# Altium schematic sheet Sheet1.SchDoc
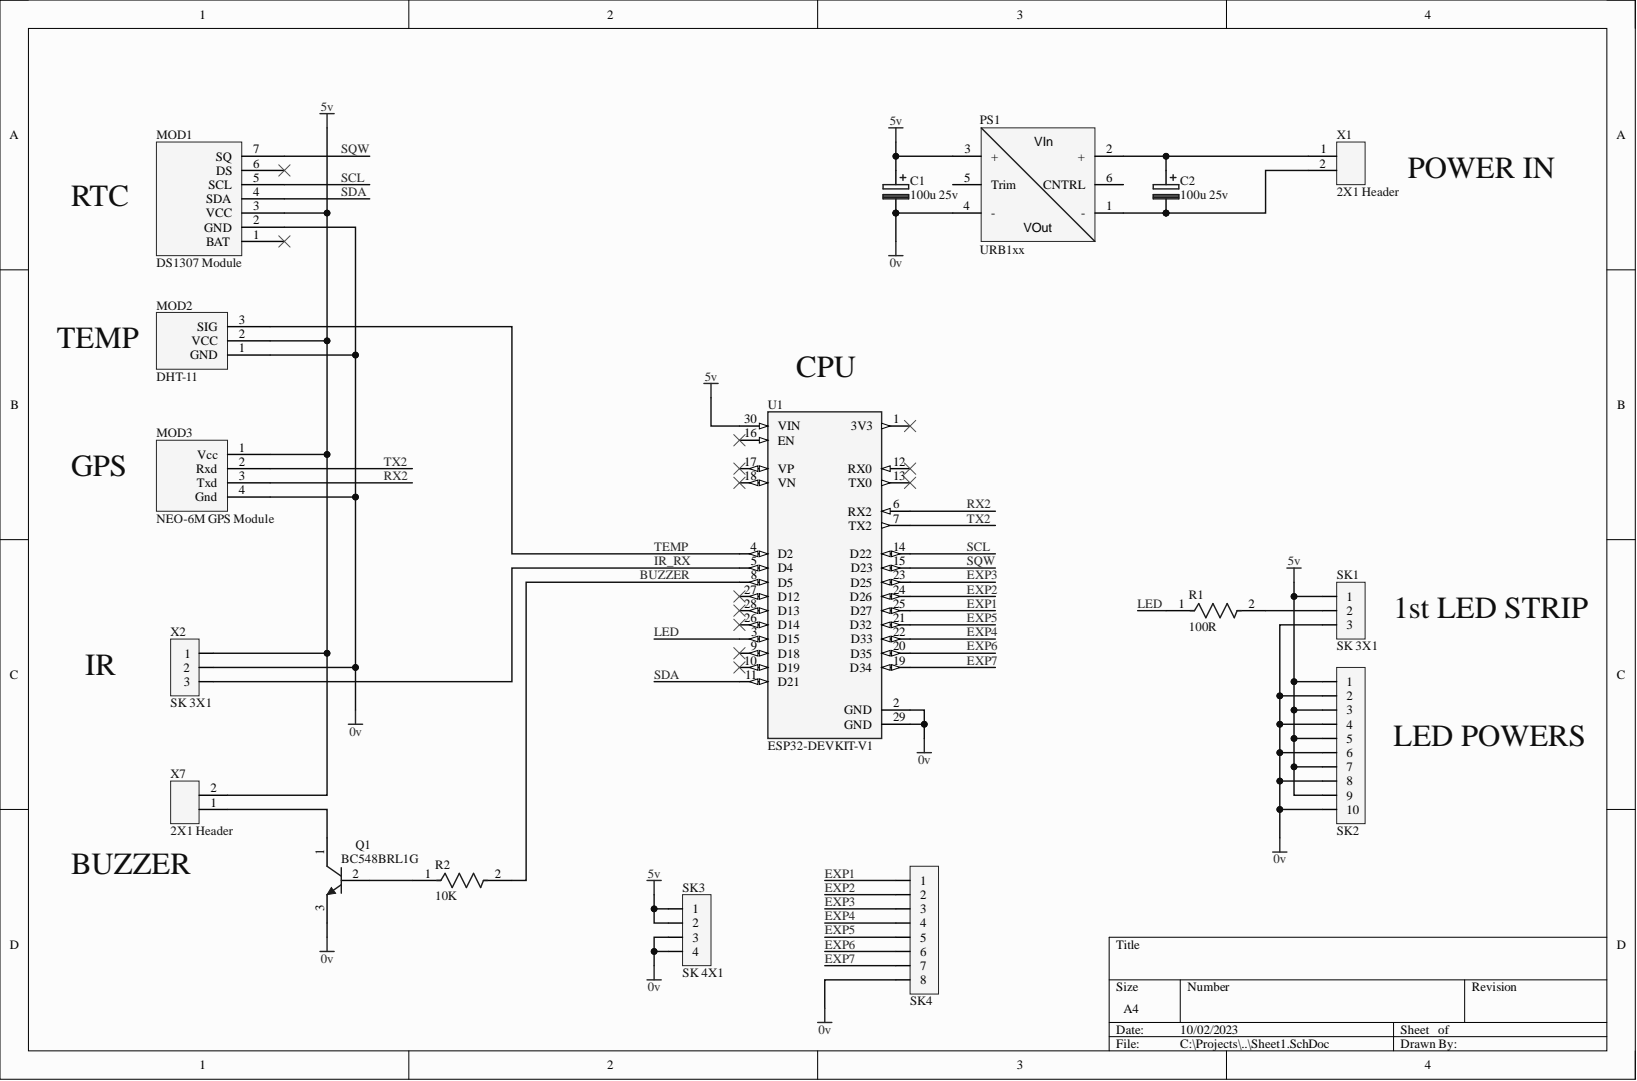
[diagram p0: Sheet1.SchDoc]

## Parts
C1  100u 25v  [RB 2.5/6]
C2  100u 25v  [RB 2.5/6]
MOD1  DS1307 Module  [DS1307 Module]
MOD2  DHT-11  [DHT11]
MOD3  NEO-6M GPS Module  [GY-GPS6MV2]
PS1  URB1xx  [PS DC/DC DA0xx]
Q1  BC548BRL1G  [ONSC-TO-92-3-29-11_AM_BL]
R1  100R  [FP-CF18-MFG]
R2  10K  [FP-CF18-MFG]
SK1  SK 3X1  [TB 3X1 5.08 V]
SK2  SK 10X1  [SK 10x1 5.08 R/A]  (value not drawn)
SK3  SK 4X1  [SK 4X1 Vert M]
SK4  SK 8X1  [SK 8X1 2.54 V]  (value not drawn)
U1  ESP32-DEVKIT-V1  [MODULE_ESP32_DEVKIT_V1]
X1  2X1 Header  [TB 2X1 5.08 V]
X2  SK 3X1  [TB 3X1 5.08 V]
X7  2X1 Header  [TB 2X1 5.08 V]

## Nets
0v: C1.2 MOD1.2(GND) MOD2.1 MOD3.4(Gnd) PS1.4(-) Q1.3 SK1.3 SK2.2 SK2.4 SK2.6 SK2.8 SK2.10 SK3.3 SK3.4 SK4.8 U1.2(GND) U1.29(GND) X2.2
5v: C1.1 MOD1.3(VCC) MOD2.2 MOD3.1(Vcc) PS1.3(+) SK1.1 SK2.1 SK2.3 SK2.5 SK2.7 SK2.9 SK3.1 SK3.2 U1.30(VIN) X2.1 X7.2
BUZZER: R2.2 U1.8(D5)
EXP1: SK4.1 U1.25(D27)
EXP2: SK4.2 U1.24(D26)
EXP3: SK4.3 U1.23(D25)
EXP4: SK4.4 U1.22(D33)
EXP5: SK4.5 U1.21(D32)
EXP6: SK4.6 U1.20(D35)
EXP7: SK4.7 U1.19(D34)
IR_RX: U1.5(D4) X2.3
LED: R1.1 U1.3(D15)
RX2: MOD3.3(Txd) U1.6(RX2)
SCL: MOD1.5(SCL) U1.14(D22)
SDA: MOD1.4(SDA) U1.11(D21)
SQW: MOD1.7(SQ) U1.15(D23)
TEMP: MOD2.3 U1.4(D2)
TX2: MOD3.2(Rxd) U1.7(TX2)
NetC2_1: C2.1 PS1.2(+) X1.1
NetC2_2: C2.2 PS1.1(-) X1.2
NetQ1_1: Q1.1 X7.1
NetQ1_2: Q1.2 R2.1
NetR1_2: R1.2 SK1.2

Not connected: MOD1 1(BAT) 6(DS); PS1 5(Trim) 6(CNTRL); U1 1(3V3) 9(D18) 10(D19) 12(RX0) 13(TX0) 16(EN) 17(VP) 18(VN) 26(D14) 27(D12) 28(D13)

## Library data of the parts
C1 C2 = 1005: C 100uF 25V 2.5mm pins; Manufacturer: Panasonic; AverageCost: 0.1; Database Table Name: vwAltiumCapacitors; GroupDesc: Capacitors; ProductCode: EEUFM1E101; SubGroupDesc: Through Hole Capacitors Tantalum /Electrolytic; Component Kind: Standard; ComponentID: 1005; Package: RB 2.5/6; StockCode: 212-0014; Library Name: SystemCORP.DbLib
PS1 = 2001: DC/DC Converter 9-36v 6W; Manufacturer: Mornsun; AverageCost: 7; Database Table Name: vwAltiumElectronicModules; GroupDesc: Electronic Modules; ProductCode: URB2405YMD-6WR3; SubGroupDesc: Generic Group for Electronic Modules; Component Kind: Standard; ComponentID: 2001; Package: PS DC/DC DA0xx dual; StockCode: 700-0044; Library Name: SystemCORP.DbLib
Q1 = CMP-1048-01603-1: Amplifier Transistor, NPN Silicon, 3-Pin TO-92, Pb-Free, Tape and Reel; Manufacturer: On Semiconductor; fT Min (MHz): 150; IC Continuous (A): 0.1; Component Kind: Standard; ComponentLink1Description: Manufacturer URL; ComponentLink1URL: http://www.onsemi.com/; ComponentLink2Description: Datasheet; ComponentLink2URL: http://www.onsemi.com/pub_link/Collateral/BC546-D.PDF; ComponentLink3Description: Package Specification; ComponentLink3URL: http://www.onsemi.com/pub_link/Collateral/MAC97-D.PDF; DatasheetVersion: Rev. 7; Library Name: Altium Content Vault; Mounting Technology: Through Hole; PackageDescription: 3-Pin Transistor Outline, Body 4.825 x 3.685 mm, Pitch 1.27 mm; PackageReference: TO-92-3-29-11_AM_BL; PackageVersion: Rev. AM; Packing: Tape and Reel; PartNumber: BC548BRL1G; Polarity: NPN; RoHS: TRUE
R1 R2 = CMP-26528-000002-1: RES 10K OHM 1/8W 5% CF AXIAL; Manufacturer: Stackpole Electronics; Manufacturer Part Number: CF18JT10K0; Power Rating: 0.125W; Resistance: 10kΩ; Temperature Coefficient: 0-500ppm/°C; Value: 10kΩ; Voltage Rating: 250V; Automotive: No; Category: Res; Component Kind: Standard; ComponentLink2Description: Datasheet; ComponentLink2URL: http://www.seielect.com/Catalog/SEI-CF_CFM.pdf; Composition: Carbon Film; Device Class L1: Passive Components; Device Class L2: Resistors; Device Class L3: Through-Hole Resistors; Digikey Description: RES 10K OHM 1/8W 5% CF AXIAL; Height: 2mm; Ipc Land Pattern Name: RESAD656W65L360D200; Lead Free: Yes; Library Name: Altium Content Vault; Octopart: https://octopart.com/cf18jt10k0-stackpole+electronics-19205642; Package: CF18; RoHS: TRUE; Series: CF; Temperature: -55°C to +155°C; Temperature Range High: +155°C; Temperature Range Low: -55°C; Tolerance: 5%
SK1 X2 = 1151: TB 3way 5.08 Vert Screw.; Manufacturer: Ningbo New Sanhe Electric Contact Co., Ltd.; AverageCost: 0.3; Database Table Name: vwAltiumSwitchesAndConnectors; GroupDesc: Switches and Connectors; ProductCode: SH152-5.08-3P; SubGroupDesc: Through Hole Connectors /Sockets; Component Kind: Standard; ComponentID: 1151; Package: TB 3X1 5.08 V; StockCode: 232-0093; Library Name: SystemCORP.DbLib
SK2 = 879: SK 10 Way 5.08mm R/A with side walls; Manufacturer: Ningbo New Sanhe Electric Contact Co., Ltd.; AverageCost: 0.25; Database Table Name: vwAltiumSwitchesAndConnectors; GroupDesc: Switches and Connectors; Notes: obsolete! green connector.; ProductCode: SH230RA-5.08-10P; SubGroupDesc: Through Hole Connectors /Sockets; Component Kind: Standard; ComponentID: 879; Package: SK 10x1 5.08 R/A; StockCode: 232-0066; Library Name: SystemCORP.DbLib
SK3 = 1427: SK 4way SIL Header 2.54 V, M; Manufacturer: Shenzhen Scondar Industrial CO.,LTD; AverageCost: 0.1; Database Table Name: vwAltiumSwitchesAndConnectors; GroupDesc: Switches and Connectors; Notes: Mated height 10 mm when mated with 232-0194; ProductCode: PH254H150-ST-1X4P; SubGroupDesc: Through Hole Connectors /Sockets; Component Kind: Standard; ComponentID: 1427; Package: SK 4X1 Vert M; StockCode: 232-0117; Library Name: SystemCORP.DbLib
SK4 = 2260: SK 8Way SIL pin header; Manufacturer: Gaurang Electronics Industries; AverageCost: 0.04; Database Table Name: vwAltiumSwitchesAndConnectors; GroupDesc: Switches and Connectors; ProductCode: DZ254R-11-08-63; SubGroupDesc: Through Hole Connectors /Sockets; Component Kind: Standard; ComponentID: 2260; Package: SK 8X1 2.54 V; StockCode: 232-0200; Library Name: SystemCORP.DbLib
U1 = ESP32-DEVKIT-V1: Dual core, Wi-Fi: 2.4 GHz up to 150 Mbits/s,BLE (Bluetooth Low Energy) and legacy Bluetooth, 32 bits, Up to 240 MHz; MF: Do it; Availability: Not in stock; Check_prices: https://www.snapeda.com/parts/ESP32-DEVKIT-V1/Do+it/view-part/?ref=eda; Component Kind: Standard; Library Name: Schlib1.SchLib; MP: ESP32-DEVKIT-V1; Package: None; Price: None; SnapEDA_Link: https://www.snapeda.com/parts/ESP32-DEVKIT-V1/Do+it/view-part/?ref=snap
X1 X7 = 1150: TB 2way 5.08 Vert Screw.; Manufacturer: Ningbo New Sanhe Electric Contact Co., Ltd.; AverageCost: 0.3; Database Table Name: vwAltiumSwitchesAndConnectors; GroupDesc: Switches and Connectors; ProductCode: SH152-5.08-2P; SubGroupDesc: Through Hole Connectors /Sockets; Component Kind: Standard; ComponentID: 1150; Package: TB 2X1 5.08 V; StockCode: 232-0092; Library Name: SystemCORP.DbLib
MOD1 = DS1307 Module: Component Kind: Standard; Library Name: Schlib1.SchLib; Source: https://www.aliexpress.com/item/1005004956749405.html
MOD2 = DHT-11: Component Kind: Standard; Library Name: Schlib1.SchLib; Source: https://www.aliexpress.com/item/1005004347626869.html
MOD3 = NEO-6M GPS Module: Component Kind: Standard; Library Name: Schlib1.SchLib
